# Altium schematic sheet power.SchDoc(power)
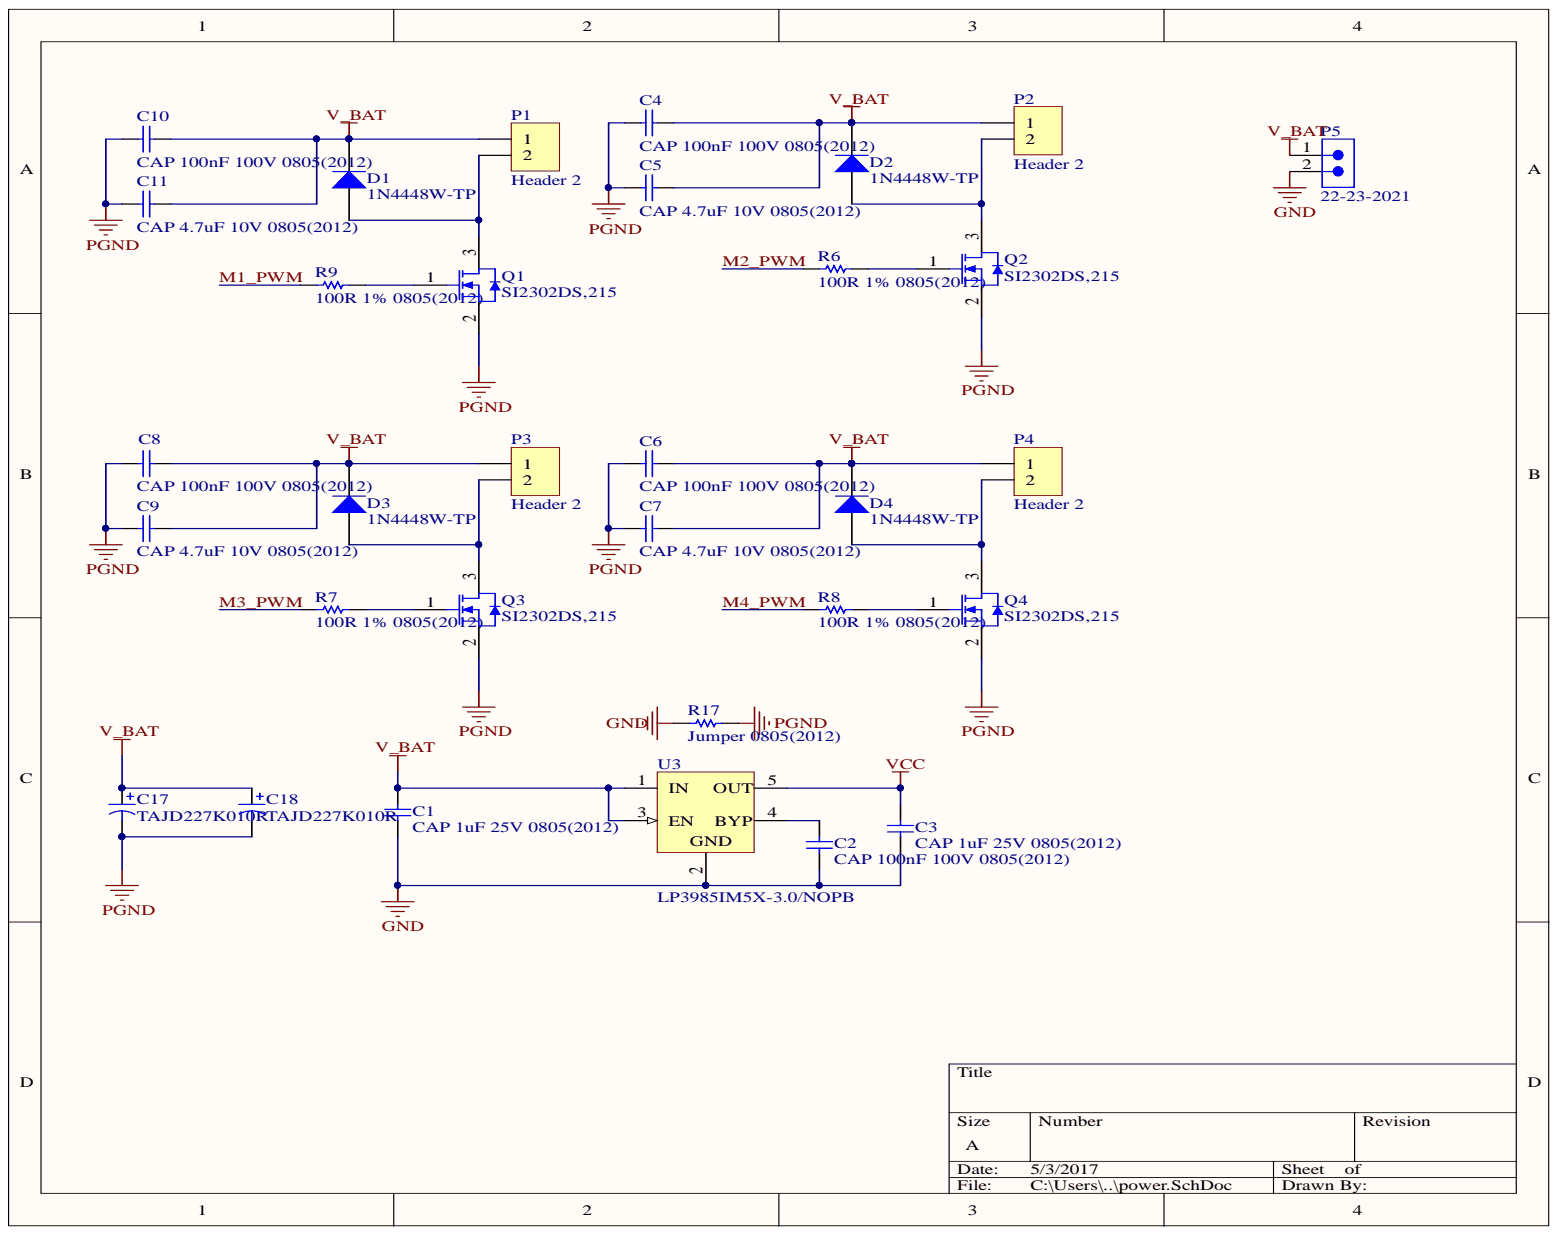
[diagram p0: power.SchDoc]

## Parts
C1  CAP 1uF 25V 0805(2012)  value 1uF  [CAPC0805(2012)100_M]
C2  CAP 100nF 100V 0805(2012)  value 100nF  [CAPC0805(2012)145_N]
C3  CAP 1uF 25V 0805(2012)  value 1uF  [CAPC0805(2012)100_M]
C4  CAP 100nF 100V 0805(2012)  value 100nF  [CAPC0805(2012)145_N]
C5  CAP 4.7uF 10V 0805(2012)  value 4.7uF  [CAPC0805(2012)155_L]
C6  CAP 100nF 100V 0805(2012)  value 100nF  [CAPC0805(2012)145_N]
C7  CAP 4.7uF 10V 0805(2012)  value 4.7uF  [CAPC0805(2012)155_L]
C8  CAP 100nF 100V 0805(2012)  value 100nF  [CAPC0805(2012)145_N]
C9  CAP 4.7uF 10V 0805(2012)  value 4.7uF  [CAPC0805(2012)155_L]
C10  CAP 100nF 100V 0805(2012)  value 100nF  [CAPC0805(2012)145_N]
C11  CAP 4.7uF 10V 0805(2012)  value 4.7uF  [CAPC0805(2012)155_L]
C17  TAJD227K010R  [AVX-CAPC7343_V]
C18  TAJD227K010R  [AVX-CAPC7343_V]
D1  1N4448W-TP  [MCC-SOD123_V]
D2  1N4448W-TP  [MCC-SOD123_V]
D3  1N4448W-TP  [MCC-SOD123_V]
D4  1N4448W-TP  [MCC-SOD123_V]
P1  Header 2  [HDR1X2]
P2  Header 2  [HDR1X2]
P3  Header 2  [HDR1X2]
P4  Header 2  [HDR1X2]
P5  22-23-2021  [MOLX-22-23-2021_V]
Q1  SI2302DS,215  [NXP-SOT23_N]
Q2  SI2302DS,215  [NXP-SOT23_N]
Q3  SI2302DS,215  [NXP-SOT23_N]
Q4  SI2302DS,215  [NXP-SOT23_N]
R6  100R 1% 0805(2012)  [RESC0805(2012)_L]
R7  100R 1% 0805(2012)  [RESC0805(2012)_L]
R8  100R 1% 0805(2012)  [RESC0805(2012)_L]
R9  100R 1% 0805(2012)  [RESC0805(2012)_L]
R17  Jumper 0805(2012)  [RESC0805(2012)_N]
U3  LP3985IM5X-3.0/NOPB  [MF05A_L]

## Nets
GND: C1.1 C2.1 C3.1 P5.2 R17.1 U3.2
PGND: C4.1 C5.1 C6.1 C7.1 C8.1 C9.1 C10.1 C11.1 C17.2 C18.2 Q1.2 Q2.2 Q3.2 Q4.2 R17.2
V_BAT: C1.2 C4.2 C5.2 C6.2 C7.2 C8.2 C9.2 C10.2 C11.2 C17.1 C18.1 D1.2 D2.2 D3.2 D4.2 P1.1 P2.1 P3.1 P4.1 P5.1 U3.1(IN) U3.3(EN)
VCC: C3.2 U3.5(OUT)
M1_PWM: R9.1
M2_PWM: R6.1
M3_PWM: R7.1
M4_PWM: R8.1
NetC2_2: C2.2 U3.4(BYP)
NetD1_1: D1.1 P1.2 Q1.3
NetD2_1: D2.1 P2.2 Q2.3
NetD3_1: D3.1 P3.2 Q3.3
NetD4_1: D4.1 P4.2 Q4.3
NetQ1_1: Q1.1 R9.2
NetQ2_1: Q2.1 R6.2
NetQ3_1: Q3.1 R7.2
NetQ4_1: Q4.1 R8.2

## Library data of the parts
C1 C3 = CMP-1036-04754-1: CAP 1uF 25V ±10% 0805 (2012 Metric) Thickness 1mm SMD; Tolerance: Â±10%; Case-Metric: 2012; Case-EIA: 0805; Technology: SMT; Rated Voltage: 25 V; Max Thickness: 1 mm; Library Name: Altium Content Vault; Component Kind: Standard
C2 C4 C6 C8 C10 = CMP-1036-04431-1: CAP 100nF 100V ±20% 0805 (2012 Metric) Thickness 1.45mm SMD; Tolerance: Â±20%; Case-Metric: 2012; Case-EIA: 0805; Technology: SMT; Rated Voltage: 100 V; Max Thickness: 1.45 mm; Library Name: Altium Content Vault; Component Kind: Standard
  C2: Value: 100nF
C5 C7 C9 C11 = CMP-1036-04882-1: CAP 4.7uF 10V ±10% 0805 (2012 Metric) Thickness 1.55mm SMD; Tolerance: Â±10%; Case-Metric: 2012; Case-EIA: 0805; Technology: SMT; Rated Voltage: 10 V; Max Thickness: 1.55 mm; Library Name: Altium Content Vault; Component Kind: Standard
C17 C18 = CMP-0279-00002-2: Capacitor, Tantalum, 220uF 10% 10V MCCT-D (7343-31); Manufacturer: AVX; Polarity: Polarized; Tolerance: 10%; Technology: Tantalum; Rated Voltage: 10V; PartNumber: TAJD227K010R; PackageReference: CAPC7343; PackageDescription: 2-Pin SMT Capacitor, 7.3 x 4.3 mm Body; Mounting Technology: Surface Mount; Library Name: Altium Content Vault; DatasheetVersion: Jul-13; ComponentLink2URL: http://www.avx.com/docs/Catalogs/taj.pdf; ComponentLink2Description: Datasheet; ComponentLink1URL: http://www.avx.com/; ComponentLink1Description: Manufacturer URL; Component Kind: Standard; Capacitance: 220uF
D1-D4 = CMP-0862-00001-1: Switching Diode, 500 mW, 100 V, -55 to 150 degC, SOD123, RoHS, Tape and Reel; Manufacturer: Micro Commercial Components; VRM (PRV): 100 V; Typical Junction Capacitance: 4 pF; Trr: 4 nS; PD: 500 mW; IR: 25 nA; IF (AV): 250 mA; FV: 1 V Max.; RoHS: TRUE; PartNumber: 1N4448W-TP; Packing: Tape and Reel; PackageVersion: Rev. C; PackageReference: SOD123; PackageDescription: 2-Pin SOD123, Body 2.7 x 1.6 mm, Height 1.35 mm; Operating Temperature Range: -55 to 150 degC; Mounting Technology: Surface Mount; Library Name: Altium Content Vault; DatasheetVersion: Rev. C; ComponentLink3URL: http://www.mccsemi.com/up_pdf/1N4448W(SOD-123).pdf; ComponentLink3Description: Package Specification; ComponentLink2URL: http://www.mccsemi.com/up_pdf/1N4448W(SOD-123).pdf; ComponentLink2Description: Datasheet; ComponentLink1URL: http://www.mccsemi.com/; ComponentLink1Description: Manufacturer URL; Component Kind: Standard
P1-P4 = Header 2: Header, 2-Pin; Signal Integrity: Connector; Library Name: Miscellaneous Connectors.IntLib; LatestRevisionNote: Re-released for DXP Platform.; LatestRevisionDate: 17-Jul-2002; Component Kind: Standard; Publisher: Altium Limited
P5 = CMP-1704-00001-3: Male Header, Pitch 2.54 mm, 1 x 2 Position, Height 10.67 mm, Tail Length 3.56 mm, 0 to 75 degC, RoHS, Bulk; Width: 6.35 mm; RoHSCompliant: True; REACH SVHC: Unknown; Radiation Hardening: No; Pins: 2; PartNumber: 22-23-2021; Packaging: Bulk; Package Quantity: 3000; PackageDescription: Male Header, Pitch 2.54 mm, 1 x 2 Position, Height 10.67 mm, Tail Length 3.56 mm; Mounting Technology: Through Hole; Mount: Through Hole; Min Operating Temperature: 0 degC; Max Operating Temperature: 75 degC; Library Name: Altium Content Vault; Length: 5.08 mm; Height: 10.67 mm; ComponentLink2URL: https://datasheet.ciiva.com/19454/232266-19454304.pdf; ComponentLink2Description: Datasheet; ComponentLink1URL: http://www.molex.com/; ComponentLink1Description: Manufacturer URL; Component Kind: Standard
Q1-Q4 = CMP-1258-00133-1: N-Channel Enhancement Mode Field-Effect Transistor, 20 V, 2.5 A, 3-Pin SOT23, Tape and Reel; Manufacturer: NXP Semiconductors; VDS [max] (V): 20; Packing: Tape & Reel; ID [max] (A): 2.5; Coss [typ] (pF): 125; Ciss [typ] (pF): 230; Channel Type: N; PartNumber: SI2302DS,215; PackageVersion: 06-03-16, 03/2006; PackageReference: SOT23; PackageDescription: 3-Pin Small Outline Transistor Package, Body 2.9 x 1.3 mm, Pitch 0.95 mm; Mounting Technology: Surface Mount; Library Name: Altium Content Vault; DatasheetVersion: Rev. 02; ComponentLink3URL: http://www.nxp.com/documents/outline_drawing/sot023_po.pdf; ComponentLink3Description: Package Specification; ComponentLink2URL: http://www.nxp.com/documents/data_sheet/SI2302DS.pdf; ComponentLink2Description: Datasheet; ComponentLink1URL: http://www.nxp.com/; ComponentLink1Description: Manufacturer URL; Component Kind: Standard; Code_JEDEC: TO-236AB
R6-R9 = CMP-1013-00397-1: 100R 0.125W 1% 0805 (2012 Metric)  SMD; Value: 100.00 Ohm; Case-Metric: 2012; Case-EIA: 0805; Tolerance: 1 %; Technology: SMT; Power: 0.125 W; Library Name: Altium Content Vault; Component Kind: Standard
R17 = CMP-1013-00001-1: Jumper 0805 (2012 Metric); Value: 0.00 Ohm; Tolerance: None; Case-Metric: 2012; Case-EIA: 0805; Technology: SMT; Power: None; Library Name: Altium Content Vault; Component Kind: Standard
U3 = CMP-0062-01849-2: Micropower, 150mA Low-Noise Ultra Low-Dropout CMOS Voltage Regulator, 5-pin SOT-23, Pb-Free; Manufacturer: National Semiconductor; PowerWise: N; PackageTopMarking: LCRB*XTT; CompType: LDO IC; RoHS: Y; RevisionNote: Component URLs added; PinCount: 5; PackageVersion: revD, Aug-2008; PackageReference: MF05A; PackageDescription: Molded SOT-23, .115 x .063 x .040 in Body, 5 Lead, .0375 in Pitch; Library Name: Altium Content Vault; DatasheetVersion: Aug-2005; ComponentLink3URL: http://www.national.com/packaging/folders/mf05a.html; ComponentLink3Description: MF05A Package Page; ComponentLink2URL: http://www.national.com/pf/LP/LP3985.html; ComponentLink2Description: LP3985 Web Page; ComponentLink1URL: http://www.national.com/ds/LP/LP3985.pdf; ComponentLink1Description: LP3985 Datasheet; Component Kind: Standard
